# Altium schematic sheet 01_Power_IN.SchDoc(01_Power_IN)
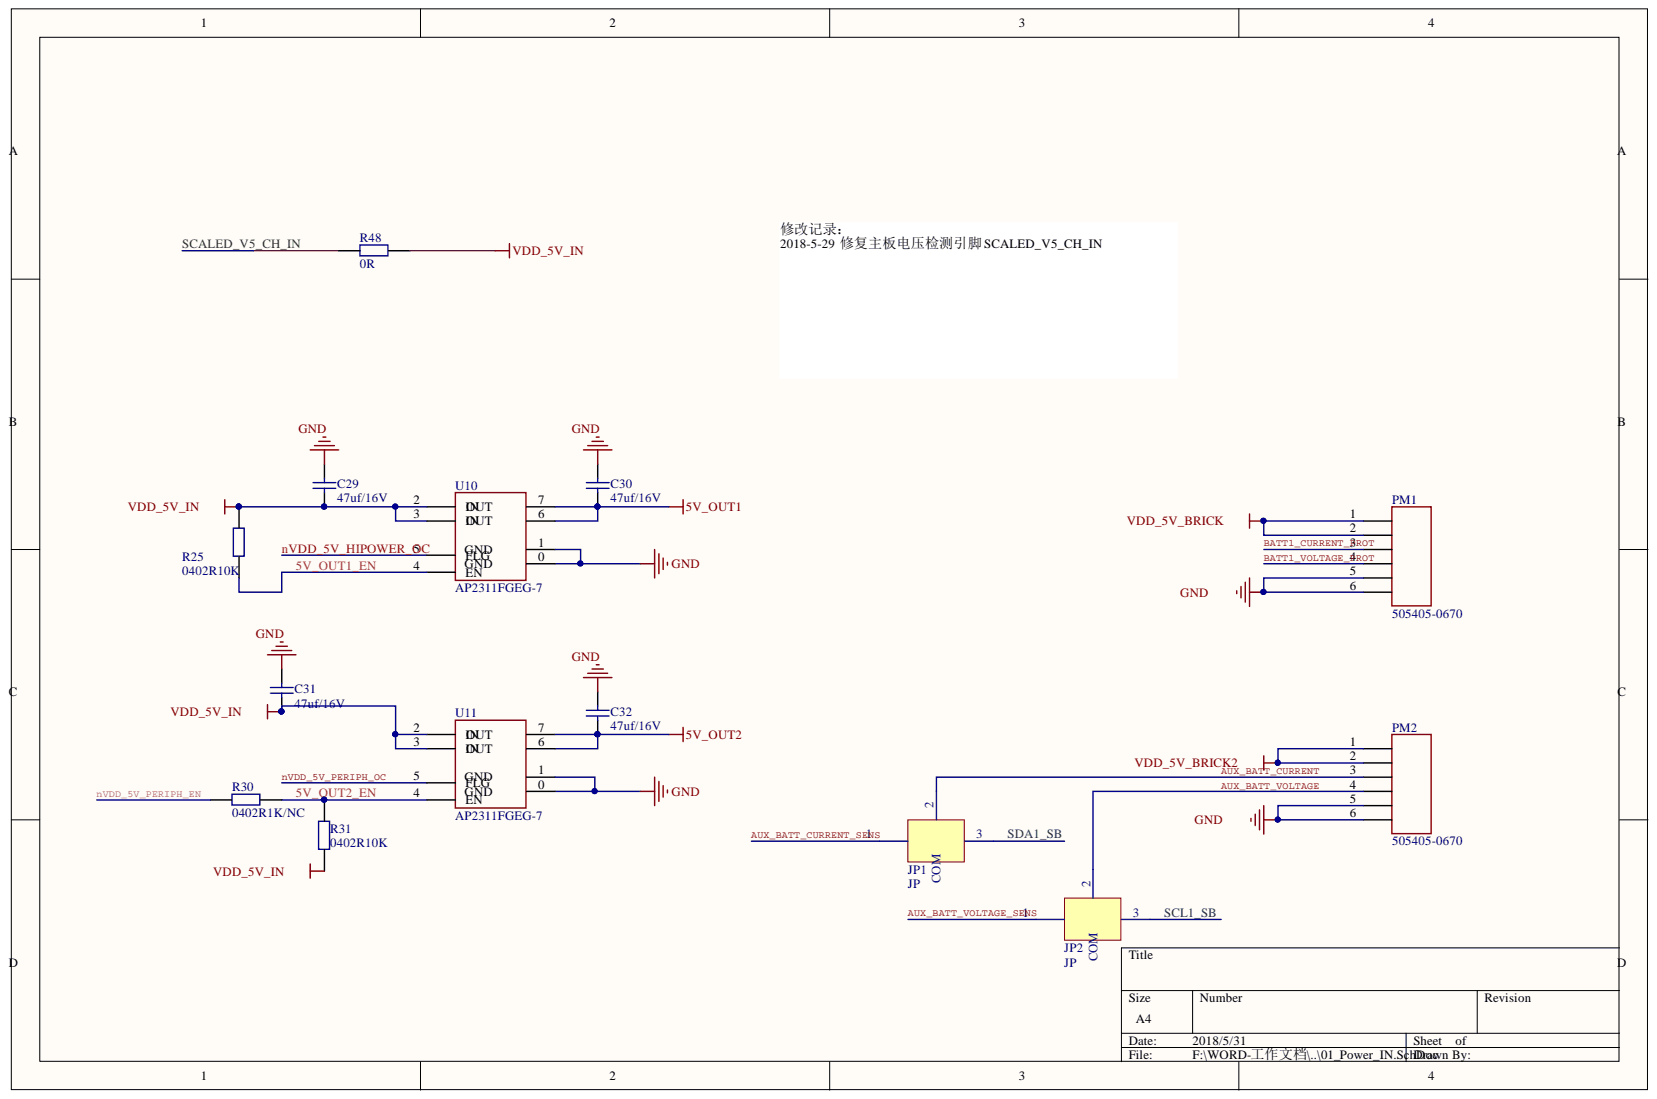
[diagram p0: 01_Power_IN.SchDoc]

## Parts
C29  47uf/16V  [0805CAP]
C30  47uf/16V  [0805CAP]
C31  47uf/16V  [0805CAP]
C32  47uf/16V  [0805CAP]
JP1  JP  [0402R-SR]
JP2  JP  [0402R-SR]
PM1  505405-0670  [5054050660]
PM2  505405-0670  [5054050660]
R25  0402R10K  [0402R]
R30  0402R1K/NC  [0402R]
R31  0402R10K  [0402R]
R48  0R  [0402R]
U10  AP2311FGEG-7  [QFN8_0.65]
U11  AP2311FGEG-7  [QFN8_0.65]

## Nets
5V_OUT1: C30.1 U10.6 U10.7
5V_OUT2: C32.1 U11.6 U11.7
GND: C29.2 C30.2 C31.2 C32.2 PM1.5 PM1.6 PM2.5 PM2.6 U10.0 U10.1 U11.0 U11.1
VDD_5V_BRICK: PM1.1 PM1.2
VDD_5V_BRICK2: PM2.1 PM2.2
VDD_5V_IN: C29.1 C31.1 R25.2 R31.2 R48.2 U10.2(IN) U10.3(IN) U11.2(IN) U11.3(IN)
5V_OUT1_EN: R25.1 U10.4(EN)
5V_OUT2_EN: R30.1 R31.1 U11.4(EN)
AUX_BATT_CURRENT: JP1.2 PM2.3
AUX_BATT_CURRENT_SENS: JP1.1
AUX_BATT_VOLTAGE: JP2.2 PM2.4
AUX_BATT_VOLTAGE_SENS: JP2.1
BATT1_CURRENT_PROT: PM1.3
BATT1_VOLTAGE_PROT: PM1.4
NVDD_5V_HIPOWER_OC: U10.5(GND)
NVDD_5V_PERIPH_EN: R30.2
NVDD_5V_PERIPH_OC: U11.5(GND)
SCALED_V5_CH_IN: R48.1
SCL1_SB: JP2.3
SDA1_SB: JP1.3

## Library data of the parts
C29-C32 = Cap: Capacitor; Component Kind: Standard; Library Name: Miscellaneous Devices.IntLib; Signal Integrity: Cap; Simulation: CAP
JP1 JP2 = 0402R-SR: Component Kind: Standard; Library Name: CUAV.SchLib
PM1 PM2 = 5054050660: Component Kind: Standard; Library Name: CUAV.SchLib
R25 R30 R31 R48 = 0402R1K: 0402R1K 1%; Component Kind: Standard; Library Name: CUAV.SchLib
U10 U11 = AP2311FGEG-7: Component Kind: Standard; Library Name: CUAV.SchLib
